# Altium schematic sheet 02_CelClock.SchDoc
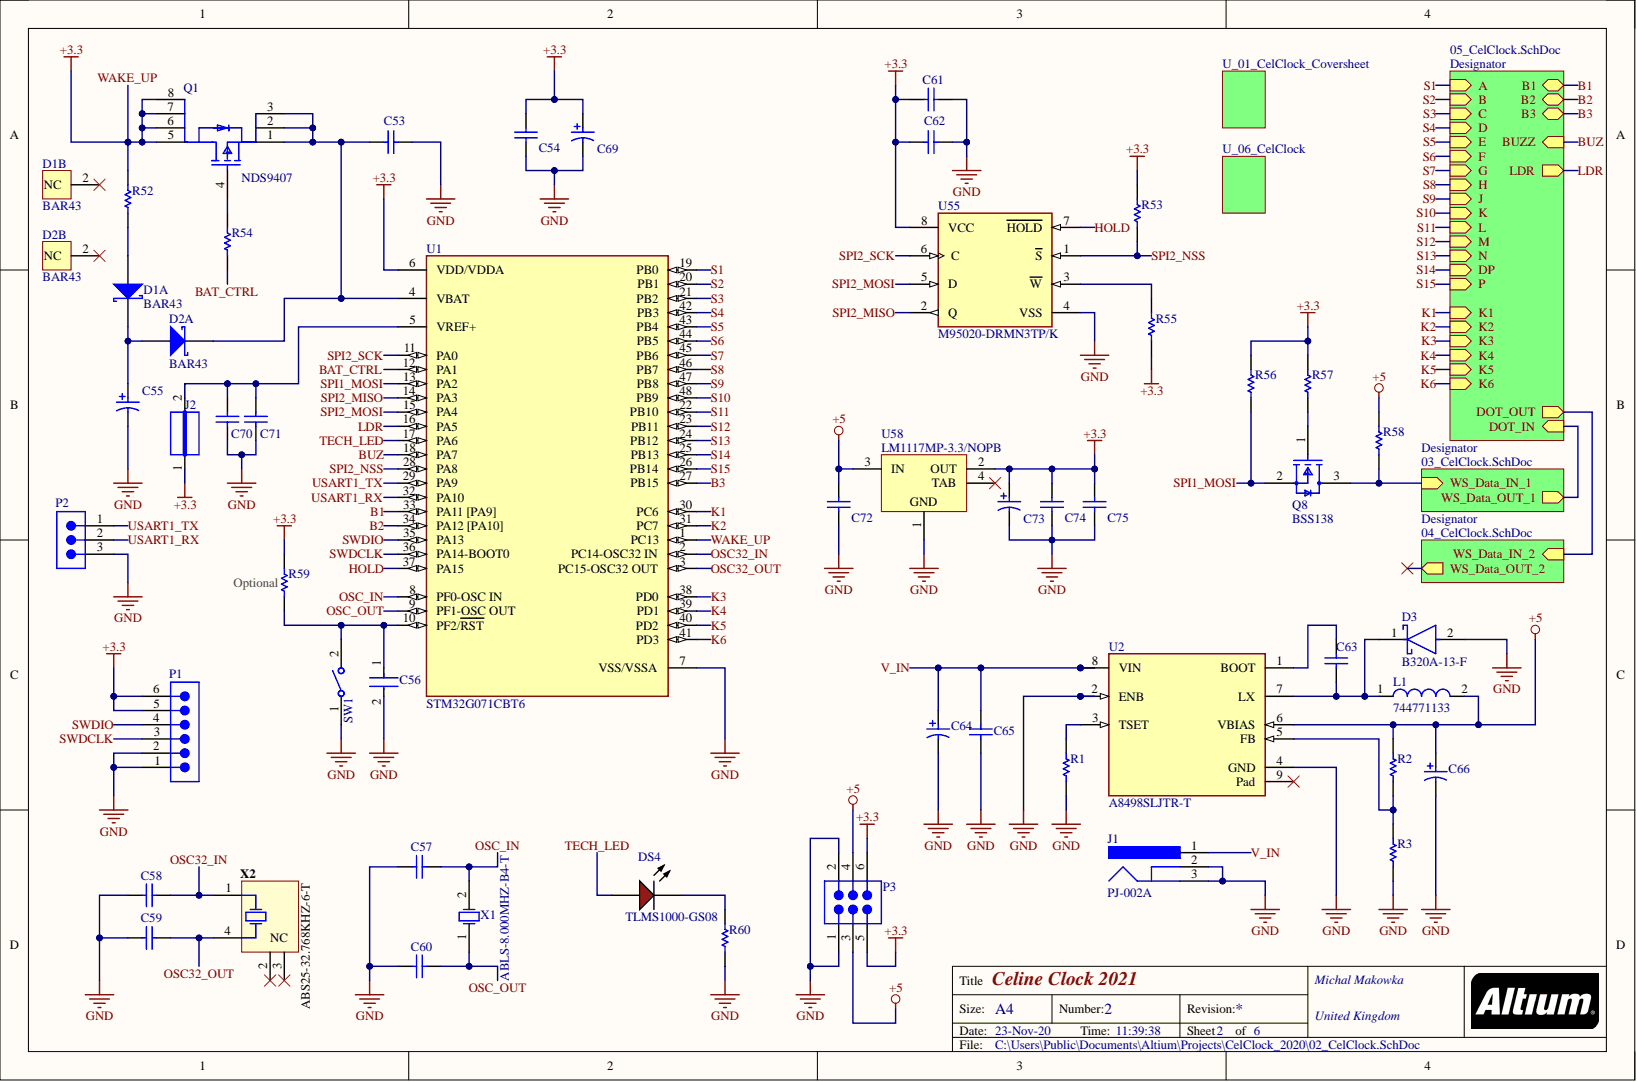
[diagram p0: 02_CelClock.SchDoc]

## Parts
C53  GRM188R71H104KA93D  [CAPC1608X90X35ML10T15]  (value not drawn)
C54  GRM188R71H104KA93D  [CAPC1608X90X35ML10T15]  (value not drawn)
C55  EECS0HD224H  [PNSC-EECS0HD224H]  (value not drawn)
C56  C0603C104K5RACTU  [FP-C0603C-CF-MFG]  (value not drawn)
C57  06031A220KAT2A  [CAPC1608X90X35LL15T15]  (value not drawn)
C58  06031A220KAT2A  [CAPC1608X90X35LL15T15]  (value not drawn)
C59  06031A220KAT2A  [CAPC1608X90X35LL15T15]  (value not drawn)
C60  06031A220KAT2A  [CAPC1608X90X35LL15T15]  (value not drawn)
C61  GRM188R71H104KA93D  [CAPC1608X90X35ML10T15]  (value not drawn)
C62  GRM188R71H104KA93D  [CAPC1608X90X35ML10T15]  (value not drawn)
C63  C0603C103K5RACTU  [CAPC1608X87X35ML15T15]  (value not drawn)
C64  EEE-FP1V221AP  [PNSC-FP-V-F_V]  (value not drawn)
C65  GRM188R71H104KA93D  [CAPC1608X90X35ML10T15]  (value not drawn)
C66  EEE-FP1V221AP  [PNSC-FP-V-F_V]  (value not drawn)
C69  EEE-FP1V221AP  [PNSC-FP-V-F_V]  (value not drawn)
C70  GRM188R71H104KA93D  [CAPC1608X90X35ML10T15]  (value not drawn)
C71  GRM188R61E105KA12D  [CAPC1608X90X35LL10T15]  (value not drawn)
C72  GRM188R71H104KA93D  [CAPC1608X90X35ML10T15]  (value not drawn)
C73  EEE-FP1V221AP  [PNSC-FP-V-F_V]  (value not drawn)
C74  GRM188R71H104KA93D  [CAPC1608X90X35ML10T15]  (value not drawn)
C75  GRM188R71H104KA93D  [CAPC1608X90X35ML10T15]  (value not drawn)
D1  BAR43  [FAIR-SOT23-3_V]  (parts A,B)
D2  BAR43  [FAIR-SOT23-3_V]  (parts A,B)
D3  B320A-13-F  [FP-SMA-MFG]
DS4  TLMS1000-GS08  [VISH-TLMS1000_V]
J1  PJ-002A  [CUI-PJ-002A_V]
J2  JUMPER  [JUMPER]  (value not drawn)
L1  744771133  [WE-PD-L]
P1  TSW-106-08-L-S-RA  [SMTC-TSW-106-08-X-S-RA]  (value not drawn)
P2  TSW-103-08-F-S-RA  [SMTC-TSW-103-08-X-S-RA]  (value not drawn)
P3  TSW-103-08-G-D  [SMTC-TSW-103-08-X-D]  (value not drawn)
Q1  NDS9407  [FP-751EB-MFG]
Q8  BSS138  [FP-318-08-IPC_B]
R1  CRCW06030000Z0EA  [RESC1609X55X30ML10T20]  (value not drawn)
R2  ERJ-3EKF1052V  [RESC1608X55X30NL15T15]  (value not drawn)
R3  ERJ-3EKF2001V  [RESC1608X55X30ML15T15]  (value not drawn)
R52  RC0603FR-07330RL  [RESC1608X55X25NL10T15]  (value not drawn)
R53  CRCW0603100KFKEA  [RESC1609X50X30LL10T20]  (value not drawn)
R54  RC0603FR-07100RL  [RESC1608X55X25ML10T15]  (value not drawn)
R55  CRCW060310K0FKEA  [RESC1609X50X30NL8T20]  (value not drawn)
R56  CRCW06032K20FKEA  [RESC1609X50X30NL10T20]  (value not drawn)
R57  CRCW06032K20FKEA  [RESC1609X50X30NL10T20]  (value not drawn)
R58  CRCW06032K20FKEA  [RESC1609X50X30NL10T20]  (value not drawn)
R59  ERJ-3GEYJ103V  [RESC1608X55X30ML15T15]  (value not drawn)
R60  RC0603FR-07330RL  [RESC1608X55X25NL10T15]  (value not drawn)
SW1  FSMSMTR  [TECO-FSMSMTR_V]  (value not drawn)
U1  STM32G071CBT6  [FP-LQFP48-5B-MFG]
U2  A8498SLJTR-T  [FP-LJ-8-IPC_C]
U55  M95020-DRMN3TP/K  [FP-SO8N-SO-A-MFG]
U58  LM1117MP-3.3/NOPB  [DCY0004A_L]
X1  ABLS-8.000MHZ-B4-T  [ABRA-ABLS-14.7456MHZ-B2-T_V]
X2  ABS25-32.768KHZ-6-T  [ABRA-ABS25-4_V]

## Nets
+5: C66.1 C72.2 L1.2 P3.3 P3.4 R2.2 R58.2 U2.6 U58.3
GND: C53.2 C54.1 C55.2 C56.2 C57.1 C58.1 C59.1 C60.1 C61.2 C62.2 C64.2 C65.1 C66.2 C69.2 C70.1 C71.1 C72.1 C73.2 C74.1 C75.1 D3.2 J1.2 J1.3 P1.1 P1.2 P2.3 P3.1 P3.2 R1.1 R3.1 R60.1 SW1.1 U1.7 U2.2 U2.4 U55.4 U58.1
B1: U1.33
B2: U1.34
B3: U1.27
BAT_CTRL: R54.1 U1.12
BUZ: U1.18
HOLD: U1.37 U55.7
K1: U1.30
K2: U1.31
K3: U1.38
K4: U1.39
K5: U1.40
K6: U1.41
LDR: U1.16
OSC32_IN: C58.2 U1.2 X2.1
OSC32_OUT: C59.2 U1.3 X2.4
OSC_IN: C57.2 U1.8 X1.2
OSC_OUT: C60.2 U1.9 X1.1
S1: U1.19
S2: U1.20
S3: U1.21
S4: U1.42
S5: U1.43
S6: U1.44
S7: U1.45
S8: U1.46
S9: U1.47
S10: U1.48
S11: U1.22
S12: U1.23
S13: U1.24
S14: U1.25
S15: U1.26
SPI1_MOSI: Q8.2 R56.1 U1.13
SPI2_MISO: U1.14 U55.2
SPI2_MOSI: U1.15 U55.5
SPI2_NSS: R53.1 U1.28 U55.1
SPI2_SCK: U1.11 U55.6
SWDCLK: P1.3 U1.36
SWDIO: P1.4 U1.35
TECH_LED: DS4.1 U1.17
USART1_RX: P2.2 U1.32
USART1_TX: P2.1 U1.29
V_IN: C64.1 C65.2 J1.1 U2.8
WAKE_UP: C54.2 C61.1 C62.1 C69.1 C73.1 C74.2 C75.2 J2.1 P1.5 P1.6 P3.5 P3.6 Q1.5 Q1.6 Q1.7 Q1.8 R52.2 R53.2 R55.1 R56.2 R57.2 R59.2 U1.1 U1.6 U55.8 U58.2
NetC53_1: C53.1 D2.3 Q1.1 Q1.2 Q1.3 U1.4
NetC55_1: C55.1 D1.3 D2.1
NetC56_1: C56.1 R59.1 SW1.2 U1.10
NetC63_1: C63.1 D3.1 L1.1 U2.7
NetC63_2: C63.2 U2.1
NetC70_2: C70.2 C71.2 J2.2 U1.5
NetD1_1: D1.1 R52.1
NetD1_2: D1.2
NetD2_2: D2.2
NetDS4_2: DS4.2 R60.2
NetQ1_4: Q1.4 R54.2
NetQ8_1: Q8.1 R57.1
NetQ8_3: Q8.3 R58.1
NetR1_2: R1.2 U2.3
NetR2_1: R2.1 R3.2 U2.5
NetR55_2: R55.2 U55.3
NetU2_9: U2.9
NetU58_4: U58.4
NetX2_2: X2.2
NetX2_3: X2.3
DOT_IN:
DOT_OUT:
WS_Data_OUT_2:

## Library data of the parts
C53 C54 C61 C62 C65 C70 C72 C74 C75 = CMP-2100-03643-1: Chip Capacitor, 0.1 uF, +/- 10%, 50 V, -55 to 125 degC, 0603 (1608 Metric), RoHS, Tape and Reel; Manufacturer: Murata; Capacitance: 100nF; Dielectric: X7R; Dielectric Material: Ceramic; Insulation Resistance: 10GR; Voltage: 50V; Case Code (Imperial): 0603; Case Code (Metric): 1608; Case/Package: 0603; Component Kind: Standard; Contact Plating: Tin; Datasheet URL: http://psearch.en.murata.com/capacitor/product/GRM188R71H104KA93%23.pdf; Datasheet Version: 42675.0; Depth: 0.8mm; Height: 0.8mm; Lead Free: LeadFree; Length: 1.6mm; Library Name: Altium Content Vault; Manufacturer URL: http://www.murata.com/; Material: Ceramic; Max Operating Temperature: 125degC; Min Operating Temperature: -55degC; Mount: SurfaceMount; Number of Pins: 2; Package Description: 2-Pin Surface Mount Device, Body 1.6 x 0.8 mm; Package Quantity: 1; Package Reference: 0603; Packaging: TapeandReel; Radiation Hardening: No; REACH SVHC: NoSVHC; RoHSCompliant: true; Termination: SMD/SMT; Thickness: 0.035inch; Tolerance: 10%; Voltage Rating: 50V; Voltage Rating (DC): 50V; Width: 0.8mm
C55 = CMP-2000-06198-1: Stacked Coin Type Capacitor, 0.22 F, +/- 80%, 5.5 VDC, -25 to 70 degC, 2-Pin THD, RoHS, Bulk; Manufacturer: Panasonic; Capacitance: 220mF; Catalog Drawings: SDSeriesHorizontalSide1    SDSeriesHorizontalTop    SDSeriesHorizontalSide2; ESR (Equivalent Series Resistance): 75Ohm@1kHz; Lifetime @ Temp.: 1000Hrs@70°C; Online Catalog: SDSeries; Other Names: EECS0HD224H  P10788; Tolerance: -20%,+80%; Voltage - Rated: 5.5V; Category: Capacitors; Component Kind: Standard; Datasheet URL: https://industrial.panasonic.com/cdbs/www-data/pdf/RDG0000/ABC0000C84.pdf; Datasheet Version: 08/2016; Family: ElectricDoubleLayerCapacitors,Supercaps; Height - Seated (Max): 0.236"(6.00mm); Lead Spacing: 0.394"(10.00mm); Library Name: Altium Content Vault; Manufacturer URL: http://www.panasonic.com/; Mounting Technology: ThroughHole; Mounting Type: ThroughHole; Operating Temperature: -25°C~70°C; Package / Case: Axial,Can-Horizontal; Package Description: 2-Pin Through Hole Device, Dia 10.5 mm (Max), Height 6 mm, Lead Spacing 10 mm; Package Reference: EECS0HD224H; Packaging: Bulk; Series: SD; Size / Dimension: 0.413"Dia(10.50mm); Standard Package: 200; Termination: ThroughHole
C56 = CMP-03020-001379-1: CAP CER 0.1UF 50V X7R 0603; Manufacturer: KEMET; Automotive Grade: Grade 1; Capacitance: 0.10 μF; Material: Ceramic; Temperature Coefficient: 30ppm/°C; Tolerance: 0.1; Value: 0.10 μF; Automotive: Yes; Category: Cap; Component Kind: Standard; ComponentLink2Description: Datasheet; ComponentLink2URL: https://api.kemet.com/component-edge/download/datasheet/C0603C100J5GACAUTO.pdf; Device Class L1: Passive Components; Device Class L2: Capacitors; Device Class L3: Ceramic Capacitors; Digikey Description: CAP CER 0.1UF 50V X7R 0603; Height: 0.87mm; Lead Free: Yes; Library Name: Altium Content Vault; Manufacturer Part Number: C0603C104K5RACTU; Octopart: https://octopart.com/c0603c104k5ractu-kemet-139244; Package: C0603C-CF; RoHS: TRUE; Temperature: -55°C to +125°C; Temperature Characteristic: X7R; Temperature Range High: +125°C; Temperature Range Low: -55°C; Voltage Rating: 50 V
C57-C60 = CMP-2006-04016-1: Manufacturer: AVX Interconnect / Elco; Component Kind: Standard; Library Name: Altium Content Vault; Part Number: 06031A220KAT2A
C63 = CMP-2006-03044-1: Manufacturer: Kemet; Component Kind: Standard; Library Name: Altium Content Vault; Part Number: C0603C103K5RACTU
C64 C66 C69 C73 = CMP-2000-06215-1: Aluminum Electrolytic Capacitor, 220 uF, +/- 20%, 35 V, 2-Pin SMD (Size Code F), -55 to 105 degC, RoHS, Tape and Reel; Manufacturer: Panasonic; AE Capacitor Case: RadialCan-SMD; Capacitance: 220µF; ESR: 0.08ohm; External Length / Height: 10.2mm; Leakage Current: 7.7µA; Life Time @ Temperature: 2000hours@105°C; Product Range: PANASONIC-FPSeries; Ripple Current AC: 850mA; SVHC: ToBeAdvised; Voltage Rating: 35V; Capacitance Tolerance: ±20%; Capacitance Tolerance ±: ±20%; Capacitor Case Style: RadialCan-SMD; Component Kind: Standard; Datasheet URL: http://industrial.panasonic.com/cdbs/www-data/pdf/RDE0000/ABA0000C1184.pdf; Datasheet Version: 03/2016; Diameter: 8mm; Height: 10.2mm; Library Name: Altium Content Vault; Lifetime @ Temperature: 2000hours@105°C; Manufacturer URL: http://www.panasonic.com/; Mounting Technology: SurfaceMount; Operating Temperature Max: 105°C; Operating Temperature Min: -55°C; Operating Temperature Range: -55°Cto+105°C; Package Description: 2-Pin Electrolytic Aluminum Capacitor, Body 8.3 x 8.3 mm; Package Reference: FP-V-F; Package URL: http://industrial.panasonic.com/cdbs/www-data/pdf/RDE0000/DME0000COL48.pdf; Packaging: CutTape; Series: FP
C71 = CMP-2006-02459-1: Manufacturer: Murata; Component Kind: Standard; Library Name: Altium Content Vault; Part Number: GRM188R61E105KA12D
D1 D2 = CMP-2000-05914-1: Schottky Diode, 30 V, 200 mA, -55 to 150 degC, 3-Pin SOT-23, RoHS, Tape and Reel; Manufacturer: FairchildSemiconductor; Configuration: Single; Package Version: Rev. 12, 03/2016; Peak Average Forward Current: 0.2A; Peak Forward Voltage: 0.8@0.1AV; Peak Non-Repetitive Surge Current: 0.75A; Peak Reverse Current: 0.5@25VuA; Peak Reverse Recovery Time: 5ns; Peak Reverse Repetitive Voltage: 30V; Rad Hard: No; Category: Rectifier; Component Kind: Standard; Datasheet URL: https://www.fairchildsemi.com/datasheets/BA/BAR43.pdf; Datasheet Version: Rev. 1.3, 08/2015; Library Name: Altium Content Vault; Manufacturer URL: https://www.fairchildsemi.com/; Mounting: SurfaceMount; Mounting Technology: SurfaceMount; Operating Temperature: -55to150°C; Package: 3SOT-23; Package Description: 3-Pin Small Outline Transistor, Body 2.92 x 1.325 mm, Pitch 0.95 mm; Package Reference: SOT23-3; Package URL: http://www.fairchildsemi.com/dwg/MA/MA03D.pdf; Packaging: Tape&Reel; Type: SchottkyDiode
D3 = CMP-12790-000001-1: DIODE SCHOTTKY 20V 3A SMA; Manufacturer: Diodes Inc.; Automotive Grade: Grade 0; Capacitance: 200pF; Max Junction Temp: +150°C; Max Surge Forward Current: 80A; Peak Reverse Current: 0.5mA; Standoff Height: 0.05mm; Value: 200pF; Automotive: Yes; Category: Diode; Component Kind: Standard; ComponentLink2Description: Datasheet; ComponentLink2URL: https://www.diodes.com/assets/Datasheets/B320A_B360A.pdf; Device Class L1: Discrete Semiconductors; Device Class L2: Diodes; Device Class L3: Schottky Diodes; Digikey Description: DIODE SCHOTTKY 20V 3A SMA; Footprint Url: https://www.diodes.com/assets/Package-Files/SMA.pdf; Forward Current: 3A; Height: 2.4mm; Lead Free: Yes; Library Name: Altium Content Vault; Manufacturer Part Number: B320A-13-F; Max Repetitive Reverse Voltage: 20V; Mouser Description: Schottky Diodes & Rectifiers 20V 3A; Octopart: https://octopart.com/b320a-13-f-diodes+inc.-325803; Package: SMA; Reverse Voltage: 20V; RoHS: TRUE; Temperature: -55°C to +150°C; Temperature Range High: +150°C; Temperature Range Low: -55°C
DS4 = CMP-2000-07254-1: Low Current LED, 40 mW, 1.8 V, -40 to 100 degC, 2-Pin SMD (0603), RoHS, Tape and Reel; Manufacturer: Vishay; Automotive Qualification Standard: AEC-Q100; Bulb Size: 1.6mmx0.8mm; Forward Current If: 2mA; Forward Voltage: 1.8V; LED Colour: Red; Lens Shape: Rectangular; Luminous Intensity: 4mcd; SVHC: ToBeAdvised; Viewing Angle: 80°; Wavelength Typ: 636nm; Component Kind: Standard; Datasheet URL: https://datasheet.ciiva.com/5798/1698881-5798337.pdf; Datasheet Version: Rev. 1.7, 02/2011; LED Mounting: SMD; Library Name: Altium Content Vault; Manufacturer URL: http://www.vishay.com/; Mounting Technology: SurfaceMount; MSL: MSL2-1year; Package Description: 2-Pin Surface Mount Device, Body 1.6 x 0.8 mm; Package Reference: TLMS1000; Packaging: CutTape; Product Range: -
J1 = CMP-2000-06895-1: Through Hole Right Angle DC Power Jack, 2.5 A, 2 mm Center Pin, 3 Position, -25 to 85 degC, RoHS, Bulk; Manufacturer: CUIInc.; Brand: CUI; Current Rating: 2.5A; Inside Contact Diameter: 2mm; Insulation Resistance: 50MOhms; Outside Contact Diameter: 6.5mm; Voltage Rating: 24V; Component Kind: Standard; Connector Type: DCPowerJack; Contact Gender: Male; Contact Material: Copper; Contact Plating: Nickel; Datasheet URL: http://www.cui.com/product/resource/pj-002a.pdf; Datasheet Version: Rev. 1.03, 04/2016; Factory Pack Quantity: 500; Flammability Rating: UL94V-0; Housing Material: PolybutyleneTerephthalate(PBT); Library Name: Altium Content Vault; Manufacturer URL: http://www.cui.com/; Mounting Angle: Right; Mounting Style: ThroughHole; Mounting Technology: ThroughHole; Operating Temperature Range: -25Cto+85C; Package Description: 3-Pin Through Hole Device, Body 14.4 x 9 mm, Height 11 mm; Package Reference: PJ-002A; Product: Jacks; Product Category: DCPowerConnectors; RoHS: True; Termination Style: ThroughHole
J2 = CMP-009-00061-1: Component Kind: Standard; Description: JUMPER; Pins: 2; Library Name: QMUL Hyperlink
L1 = CMP-0227-00726-1: SMD-Shielded Power Inductor WE-PD, L = 33.0 µH; Inductance: 33.0 ÂµH; Component Kind: Standard; ComponentLink1Description: Manufacturer Link; ComponentLink1URL: http://www.we-online.com; ComponentLink2Description: Datasheet; ComponentLink2URL: http://www.we-online.de/katalog/media/pdf/744771133.pdf; DatasheetVersion: ver 6, Jun-2005; Library Name: Altium Content Vault; PackageDescription: SMD Inductor, 2-Leads, Body 12.0 x 12.0 mm; PackageReference: WE-PD-L; PackageVersion: 2006
P1 = CMP-1024-00390-1: 0.025" SQ Post Header, Through-hole, Right Angle, -55 to 125 degC, 2.54 mm Pitch, 6-Pin, Male, RoHS; Manufacturer: Samtec; Pitch: 2.54 mm; Component Kind: Standard; ComponentLink1Description: Manufacturer URL; ComponentLink1URL: http://www.samtec.com; ComponentLink2Description: Datasheet; ComponentLink2URL: http://www.samtec.com/documents/webfiles/pdf/TSW_TH.PDF; ComponentLink3Description: Package Specification; ComponentLink3URL: http://www.samtec.com/documents/webfiles/cpdf/TSW-XXX-XX-XXX-X-XX-XXX-MKT.pdf; DatasheetVersion: F-214; Library Name: Altium Content Vault; Mounting Technology: Through-hole; Orientation: Right Angle; PackageDescription: .025[0.64] SQ. Post Terminal Strip Assembly; PackageReference: TSW-106-08-X-S-RA; PackageVersion: DM, 8/1988; PartNumber: TSW-106-08-L-S-RA; RoHS: True; Temperature Range: -55 to 125 degC
P2 = CMP-1024-00344-1: 0.025" SQ Post Header, Through-hole, Right Angle, -55 to 125 degC, 2.54 mm Pitch, 3-Pin, Male, RoHS; Manufacturer: Samtec; Pitch: 2.54 mm; Component Kind: Standard; ComponentLink1Description: Manufacturer URL; ComponentLink1URL: http://www.samtec.com; ComponentLink2Description: Datasheet; ComponentLink2URL: http://www.samtec.com/documents/webfiles/pdf/TSW_TH.PDF; ComponentLink3Description: Package Specification; ComponentLink3URL: http://www.samtec.com/documents/webfiles/cpdf/TSW-XXX-XX-XXX-X-XX-XXX-MKT.pdf; DatasheetVersion: F-214; Library Name: Altium Content Vault; Mounting Technology: Through-hole; Orientation: Right Angle; PackageDescription: .025[0.64] SQ. Post Terminal Strip Assembly; PackageReference: TSW-103-08-X-S-RA; PackageVersion: DM, 8/1988; PartNumber: TSW-103-08-F-S-RA; RoHS: True; Temperature Range: -55 to 125 degC
P3 = CMP-1024-00338-1: 0.025" SQ Post Header, Through-hole, Vertical, -55 to 125 degC, 2.54 mm Pitch, 6-Pin, Male, RoHS; Manufacturer: Samtec; Pitch: 2.54 mm; Component Kind: Standard; ComponentLink1Description: Manufacturer URL; ComponentLink1URL: http://www.samtec.com; ComponentLink2Description: Datasheet; ComponentLink2URL: http://www.samtec.com/documents/webfiles/pdf/TSW_TH.PDF; ComponentLink3Description: Package Specification; ComponentLink3URL: http://www.samtec.com/documents/webfiles/cpdf/TSW-XXX-XX-XXX-X-XX-XXX-MKT.pdf; DatasheetVersion: F-214; Library Name: Altium Content Vault; Mounting Technology: Through-hole; Orientation: Vertical; PackageDescription: .025[0.64] SQ. Post Terminal Strip Assembly; PackageReference: TSW-103-08-X-D; PackageVersion: DM, 8/1988; PartNumber: TSW-103-08-G-D; RoHS: True; Temperature Range: -55 to 125 degC
Q1 = CMP-07173-000056-1: MOSFET P-CH 60V 3A 8-SOIC; Manufacturer: ON Semiconductor; Continuous Drain Current: -3A; Depletion Mode: False; Drain To Source Breakdown Voltage: -60V; Drain To Source Resistance: 122mΩ; Gate Charge At Vgs: 16nC @ -10V; Gate To Source Voltage: 20V; Input Capacitace At Vds: 732pF @ -30V; Max Forward Diode Voltage: -1.2V; Max Junction Temp: +175°C; Number Of Channels: 1; Power Dissipation: 1W; Pulse Drain Current: -12A; Reverse Recovery Charge: 66nC; Reverse Recovery Time: 24ns; Rthja Max: 125°C/W; Standoff Height: 0.1mm; Threshold Vgs Max: -3V; Threshold Vgs Min: -1V; Transconductance: 8S; Turn Off Delay Time: 10ns; Turn On Delay Time: 8ns; Typ Forward Diode Voltage: -0.8V; Automotive: No; Category: Trans; Component Kind: Standard; ComponentLink2Description: Datasheet; ComponentLink2URL: https://www.onsemi.com/pub/Collateral/NDS9407-D.PDF; Device Class L1: Discrete Semiconductors; Device Class L2: Transistors; Device Class L3: MOSFETs; Digikey Description: MOSFET P-CH 60V 3A 8-SOIC; Drain To Source Voltage: -60V; Footprint Url: https://www.onsemi.com/pub/Collateral/751EB.PDF; Height: 1.75mm; Lead Free: Yes; Library Name: Altium Content Vault; Manufacturer Part Number: NDS9407; Mouser Description: NDS9407 P-Channel MOSFET, 3 A, 60 V PowerTrench, 8-Pin SOIC ON Semiconductor; Number Of P Channels: 1; Octopart: https://octopart.com/nds9407-on+semiconductor-84330928; Package: 751EB; RoHS: TRUE; Temperature: -55°C to +175°C; Temperature Range High: +175°C; Temperature Range Low: -55°C
Q8 = CMP-07173-000101-1: MOSFET N-CH 50V 220MA SOT-23; Drain to Source Resistance: 3.5R; Element Configuration: Single; Fall Time: 9ns; Gate to Source Voltage (Vgs): 20V; Input Capacitance: 27pF; Max Power Dissipation: 360mW; Nominal Vgs: 1.3V; Number of Elements: 1; On-State Resistance: 6R; Turn-Off Delay Time: 20ns; Turn-On Delay Time: 2.5ns; Case/Package: SOT-23; Component Kind: Standard; Contact Plating: Tin; Continuous Drain Current (ID): 220mA; Current Rating: 220mA; Drain to Source Breakdown Voltage: 50V; Drain to Source Voltage (Vdss): 50V; Dual Supply Voltage: 50V; Height: 0.93mm; Lead Free: Lead Free; Length: 2.92mm; Library Name: Altium Content Vault; Max Operating Temperature: 150°C; Min Operating Temperature: -55°C; Mount: Surface Mount; Number of Pins: 3; Package Quantity: 1; Packaging: Tape and Reel; Power Dissipation: 360mW; Radiation Hardening: No; Rds On Max: 3.5R; REACH SVHC: No SVHC; Rise Time: 9ns; Termination: SMD/SMT; Threshold Voltage: 1.3V; Voltage Rating (DC): 50V; Weight: 0.03g; Width: 1.3mm
R1 = CMP-2000-03816-1: 35.2 kR; Manufacturer: Vishay Dale; Component Kind: Standard; Library Name: Altium Content Vault; Part Number: CRCW06030000Z0EA
R2 = CMP-2000-00189-1: Manufacturer: Panasonic; Component Kind: Standard; Library Name: Altium Content Vault; Part Number: ERJ-3EKF1052V
R3 = CMP-2000-00317-1: Manufacturer: Panasonic; Component Kind: Standard; Library Name: Altium Content Vault; Part Number: ERJ-3EKF2001V
R52 R60 = CMP-1659-00020-2: Chip Resistor, 330 Ohm, +/- 1%, 0.1 W, -55 to 155 degC, 0603 (1608 Metric), RoHS, Tape and Reel; Capacitance: 47 uF; ESR: 800 mohm; Tolerance: 10%; Voltage Rating: 16 V; Case Code (Imperial): 2917; Case Code (Metric): 7343; Case/Package: 2917; Component Kind: Standard; ComponentLink1Description: Manufacturer URL; ComponentLink1URL: http://www.yageo.com/; ComponentLink2Description: Datasheet; ComponentLink2URL: http://www.yageo.com/documents/recent/PYu-RC_Group_51_RoHS_L_04.pdf; DatasheetVersion: Rev. 4, 02/2016; Height: 3.1 mm; Length: 7.3 mm; Library Name: Altium Content Vault; Max Operating Temperature: 125degC; Min Operating Temperature: -55degC; Mount: Surface Mount; Package Quantity: 500; PackageDescription: 2-Pin Surface Mount Device, Body 1.6 x 0.8 mm; PackageReference: 0603; Packaging: Tape and Reel; Pins: 2; Radiation Hardening: No; REACH SVHC: No SVHC; RoHSCompliant: True; Voltage Rating (DC): 16 V; Width: 4.3 mm
R53 = CMP-2000-03820-1: Manufacturer: Vishay Dale; Component Kind: Standard; Library Name: Altium Content Vault; Part Number: CRCW0603100KFKEA
R54 = CMP-2100-03663-1: Chip Resistor, 100 Ohm, +/- 1%, 0.1 W, -55 to 155 degC, 0603 (1608 Metric), RoHS, Tape and Reel; Manufacturer: Yageo; Power Rating: 100mW; Resistance: 100Ohm; Temperature Coefficient: 100ppm/degreesC; Voltage Rating: 50V; Case Code (Imperial): 0603; Case Code (Metric): 1608; Case/Package: 0603; Component Kind: Standard; Composition: ThickFilm; Datasheet URL: http://www.yageo.com/NewPortal/yageodocoutput?fileName=/pdf/R-Chip/PYu-RC_Group_51_RoHS_L_5.pdf; Datasheet Version: Rev. 5, 10/2016; Depth: 0.8mm; Height: 0.45mm; Lead Free: LeadFree; Length: 1.6mm; Library Name: Altium Content Vault; Manufacturer URL: http://www.yageo.com/; Max Operating Temperature: 155degC; Military Standard: Not; Min Operating Temperature: -55degC; Number of Pins: 2; Package Description: 2-Pin Surface Mount Device, Body 1.6 x 0.8 mm; Package Reference: 0603; Packaging: TapeandReel; REACH SVHC: Unknown; RoHSCompliant: true; Termination: SMD/SMT; Tolerance: 1%; Voltage Rating (DC): 50V; Width: 0.8mm
R55 = CMP-1666-00005-4: Chip Resistor, 10 KOhm, +/- 1%, 100 mW, -55 to 155 degC, 0603 (1608 Metric), RoHS, Tape and Reel; Manufacturer: Vishay Semiconductor; Voltage Rating: 75 V; Component Kind: Standard; ComponentLink1Description: Manufacturer URL; ComponentLink1URL: http://www.vishay.com/; ComponentLink2Description: Datasheet; ComponentLink2URL: https://datasheet.ciiva.com/11809/0900766b80d98afc-11809037.pdf; DatasheetVersion: 06/2012; Height: 0.45 mm; Length: 1.55 mm; Library Name: Altium Content Vault; Max Operating Temperature: 155 degC; Min Operating Temperature: -55 degC; Mounting Technology: Surface Mount; Package Quantity: 5000; PackageDescription: 2-Pin Surface Mount Device, Body 1.575 x 0.85 mm; PackageReference: 0603; Packaging: Tape and Reel; REACH SVHC: Unknown; RoHSCompliant: true
R56-R58 = CMP-2000-03167-1: Manufacturer: Vishay Dale; Component Kind: Standard; Library Name: Altium Content Vault; Part Number: CRCW06032K20FKEA
R59 = CMP-2000-00704-1: Manufacturer: Panasonic; Component Kind: Standard; Library Name: Altium Content Vault; Part Number: ERJ-3GEYJ103V
SW1 = CMP-2000-07501-1: FSMSM Push Button Switch, 50 mA, -35 to 85 degC, 2-Pin SMD, RoHS, Tape and Reel; Manufacturer: TE Connectivity; Actuator Length: 1.07mm; Actuator Material: Polyamide; Case\/Package: SMD/SMT; Contact Current Rating: 50mA; Contact Resistance: 100mOhm; Electrical Life: 20000Cycles; Illumination Type: Illuminated; Max Voltage Rating (DC): 12V; Operating Force: 180gf; Switch Travel: 0.25mm; Throw Configuration: SPST; Voltage Rating (DC): 24V; Component Kind: Standard; Contact Material: Brass; Contact Plating: Silver; Current Rating: 50mA; Datasheet URL: http://www.te.com/commerce/DocumentDelivery/DDEController?Action=showdoc&DocId=Customer+Drawing%7F1437566-3%7FC2%7Fpdf%7FEnglish%7FENG_CD_1437566-3_C2_1437566_3_1_c.dwg.pdf%7F1437566-4; Datasheet Version: Rev. C2, 08/2014; ELV: Compliant; Lead Free: LeadFree; Library Name: Altium Content Vault; Manufacturer URL: http://www.te.com/; Max Operating Temperature: 85degC; Mechanical Life: 20000Cycles; Min Operating Temperature: -35degC; Mount: SurfaceMount; Mounting Technology: SurfaceMount; Orientation: Straight; Package Description: 2-Pin Surface Mount Device, Body 6 x 3.5 mm, Pitch 9.19 mm; Package Quantity: 1800; Package Reference: FSMSMTR; Packaging: TapeandReel; REACH SVHC: Unknown; RoHSCompliant: true; Termination: GullWing
U1 = CMP-12105-000052-1: IC MCU 32BIT 128KB FLASH 48LQFP; Manufacturer: STMicroelectronics; Core Architecture: ARM Cortex-M0+; Data Bus Width: 32bits; Frequency: 64MHz; Interface: I2C,SPI,UART,USART,USB,LIN,IrDA,I2S,Other; Max Junction Temp: +105°C; Max Supply Voltage: 3.6V; Memory Size: 128KBytes; Min Supply Voltage: 1.55V; Number Of A/D Converters: 1; Number Of Adc Channels: 17; Number Of I2C Channels: 2; Number Of I/Os: 44; Number Of Timers/Counters: 12; Number Of Uart Channels: 5; Ram Size: 36KBytes; Standoff Height: 0.05mm; Ambient Temperature Range High: +85°C; Ambient Temperature Range Low: -40°C; Automotive: No; Category: IC; Component Kind: Standard; ComponentLink2Description: Datasheet; ComponentLink2URL: https://www.st.com/resource/en/datasheet/stm32g071cb.pdf; Device Class L1: Integrated Circuits (ICs); Device Class L2: Embedded Processors and Controllers; Device Class L3: Microcontrollers; Digikey Description: IC MCU 32BIT 128KB FLASH 48LQFP; Height: 1.6mm; Lead Free: Yes; Library Name: Altium Content Vault; Manufacturer Part Number: STM32G071CBT6; Memory Type: FLASH; Number Of Cores: 1; Number Of Spi Channels: 2; Number Of Usb Channels: 2; Octopart: https://octopart.com/stm32g071cbt6-stmicroelectronics-96627027; Package: LQFP48-5B; RoHS: TRUE; Temperature: -40°C to +85°C; Temperature Range High: +85°C; Temperature Range Low: -40°C
U2 = CMP-82906-000002-1: IC REG BUCK ADJUSTABLE 3A 8SOIC; Max Input Voltage: 50V; Max Output Voltage: 24V; Min Input Voltage: 8V; Min Output Voltage: 800mV; Number of Outputs: 1; Output Configuration: Positive; Synchronous Rectifier: No; Case/Package: SOIC; Component Kind: Standard; Height: 1.5mm; Lead Free: Lead Free; Length: 4.9mm; Library Name: Altium Content Vault; Max Operating Temperature: 85°C; Max Output Current: 3A; Min Operating Temperature: -20°C; Mount: Surface Mount; Number of Pins: 8; Output Current: 3A; Output Type: Adjustable; Output Voltage: 24V; Package Quantity: 1; Packaging: Tape and Reel; Radiation Hardening: No; Width: 3.9mm
U55 = CMP-12142-000018-1: IC EEPROM 2K SPI 20MHZ 8SO; Manufacturer: STMicroelectronics; Access Time: 40ns; Automotive Grade: Grade 0; Frequency: 10MHz; Max Supply Voltage: 5.5V; Memory Size: 2Kbits; Min Supply Voltage: 1.7V; Nominal Supply Current: 2-5mA; Standoff Height: 0.1mm; Ambient Temperature Range High: +125°C; Ambient Temperature Range Low: -40°C; Automotive: Yes; Category: IC; Component Kind: Standard; ComponentLink2Description: Datasheet; ComponentLink2URL: https://www.st.com/content/ccc/resource/technical/document/datasheet/0a/14/2d/da/79/03/42/5e/DM00095931.pdf/files/DM00095931.pdf; Device Class L1: Integrated Circuits (ICs); Device Class L2: Memory; Device Class L3: EEPROM; Digikey Description: IC EEPROM 2K SPI 20MHZ 8SO; Height: 1.75mm; Interface: SPI; Lead Free: Yes; Library Name: Altium Content Vault; Manufacturer Part Number: M95020-DRMN3TP/K; Memory Type: EEPROM; Mouser Description: EEPROM Auto 2-Kb SPI bus EEPROM; Octopart: https://octopart.com/m95020-drmn3tp%2Fk-stmicroelectronics-31454645; Package: SO8N-SO-A; RoHS: TRUE; Temperature: -40°C to +125°C; Temperature Range High: +125°C; Temperature Range Low: -40°C
U58 = CMP-0062-01638-4: 800mA Low-Dropout Linear Regulator, 4-pin SOT-223, Pb-Free; Manufacturer: Texas Instruments; CompType: LDO IC; High-Reliability: Y; PackageTopMarking: XYTT*N05A; PowerWise: N; Code_IPC: SOT223-4; Code_JEDEC: TO-261-AA; Component Kind: Standard; ComponentLink1Description: Datasheet URL; ComponentLink1URL: http://www.ti.com/general/docs/lit/getliterature.tsp?genericPartNumber=LM1117-N&fileType=pdf; Library Name: Altium Content Vault; PackageDescription: Molded SOT-223, 6.50 x 3.56 x 1.6mm Body, 4 Lead, 2.3mm Pitch; PackageReference: DCY0004A; PartNumber: LM1117MP-3.3/NOPB; PinCount: 4; RoHS: Y
X1 = CMP-2000-05726-1: Low Profile Crystal, 8.0 MHz, +/- 30 ppm, -20 to 70 degC, 2-Pin SMD, RoHS, Tape and Reel; Brand: ABRACON; Case Height: 4.2mm; Case Length: 11.4mm; Case Width: 4.7mm; ESR: 80Ohms; Frequency: 8MHz; Frequency Stability: 50PPM; Load Capacitance: 18pF; Tolerance: 30PPM; Component Kind: Standard; Datasheet URL: http://www.abracon.com/Resonators/abls.pdf; Datasheet Version: 04/2011; Dimensions: 4.7mmWx11.4mmLx4.2mmH; Factory Pack Quantity: 1000; Library Name: Altium Content Vault; Manufacturer: ABRACON; Manufacturer URL: http://www.abracon.com/; Mounting Style: SMD/SMT; Mounting Technology: SurfaceMount; Operating Temperature Range: -20Cto+70C; Package / Case: HC-49/US; Package Description: 2-Pin Surface Mount Device, Body 11.4 x 4.7 mm; Package Reference: ABLS-14.7456MHZ-B2-T; Package URL: http://www.abracon.com/Support/PackageDrawing/Resonators/ABLS-2D.pdf; Packaging: Reel; Product Category: Crystals; RoHS: Details; Series: ABLS; Termination Style: SMD/SMT; Type: LowProfileMicroprocessorCrystal
X2 = CMP-2000-05036-1: Low Frequency Crystal, 32.768 KHz, 6 pF, +/- 20 ppm, -40 to 85 degC, 4-Pin SMD, RoHS, Tape and Reel; Brand: ABRACON; Case Height: 2.5mm; Case Length: 8mm; Case Width: 3.8mm; ESR: 50kOhms; Load Capacitance: 6pF; Tolerance: 20PPM; Component Kind: Standard; Datasheet URL: https://datasheet.ciiva.com/854/abs25-854528.pdf; Datasheet Version: Jun-17; Dimensions: 3.8mmWx8mmLx2.5mmH; Factory Pack Quantity: 3000; Frequency: 32.768kHz; Library Name: Altium Content Vault; Manufacturer: Abracon; Manufacturer URL: http://www.abracon.com/; Mounting Style: SMD/SMT; Operating Temperature Range: -40Cto+85C; Package / Case: 8mmx3.8mm; Package Description: 4-Pin Surface Mount Device, Body 8 x 3.8 mm, Pitch 5.5 mm; Package Reference: ABS25; Packaging: Reel; Product Category: Crystals; RoHS: Details; Series: ABS25; Termination Style: SMD/SMT; Type: LowFrequencyCrystal
